# Altium schematic sheet Schematic.SchDoc(Schematic)
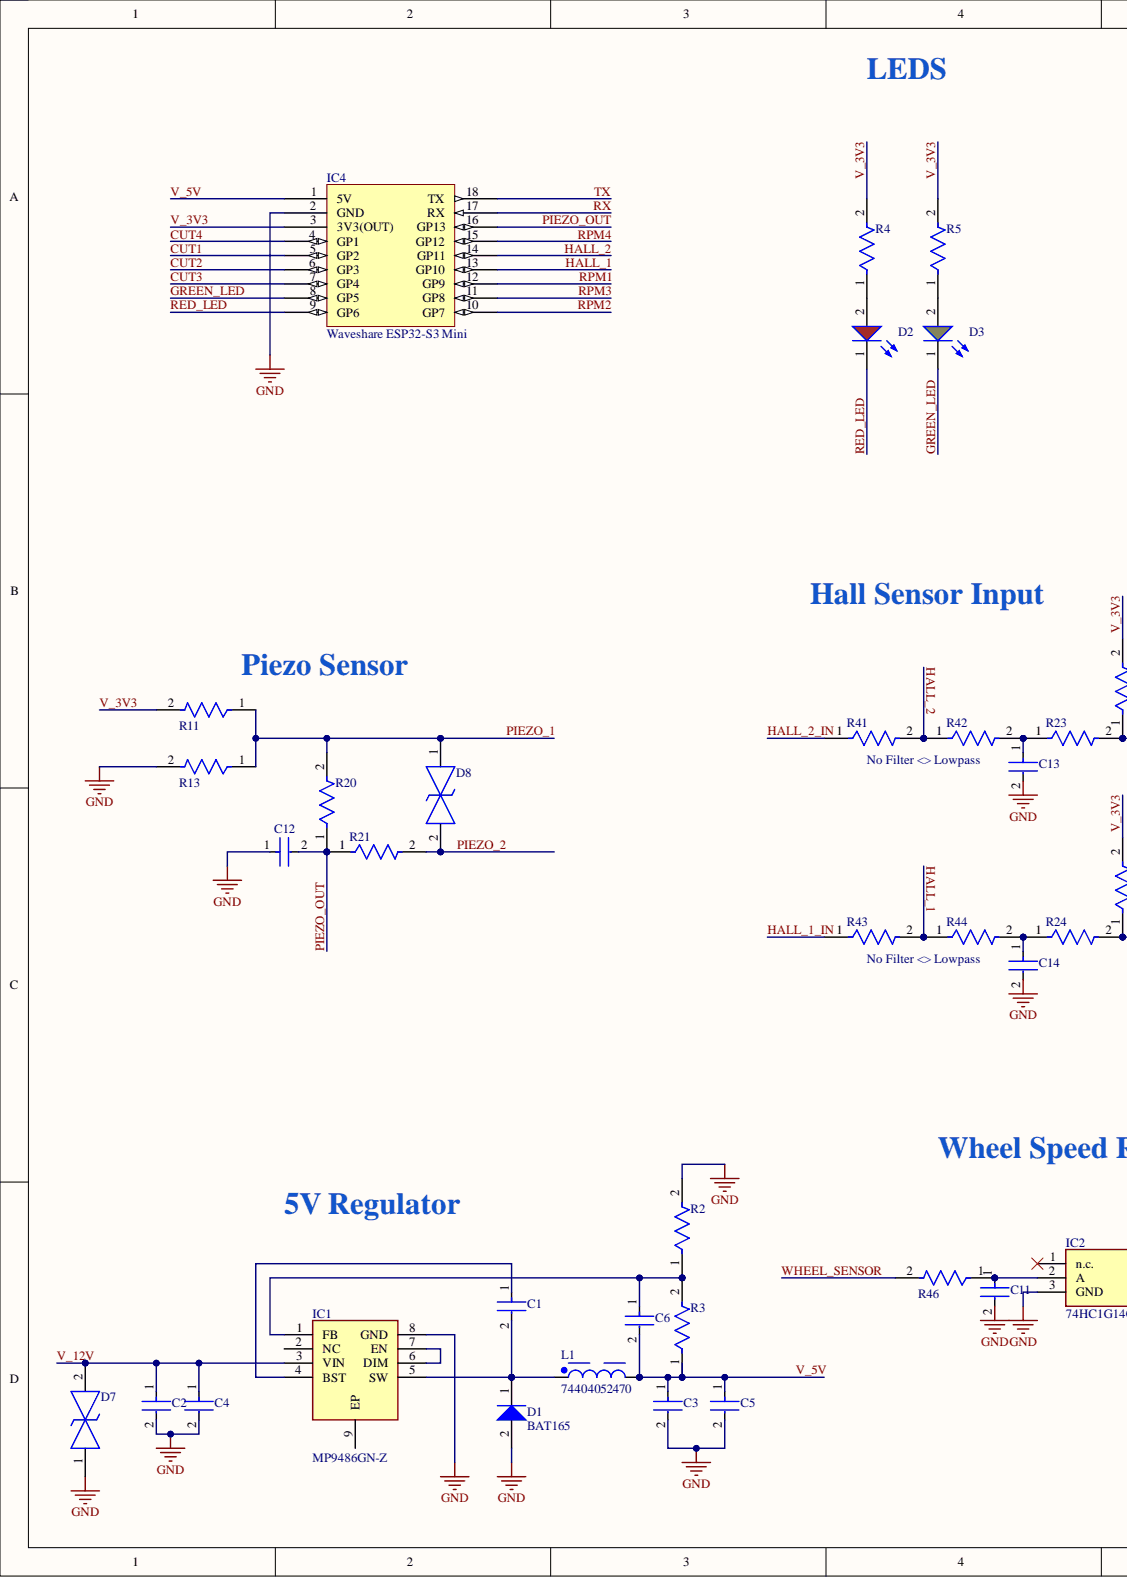
[diagram p0: Schematic.SchDoc, left]
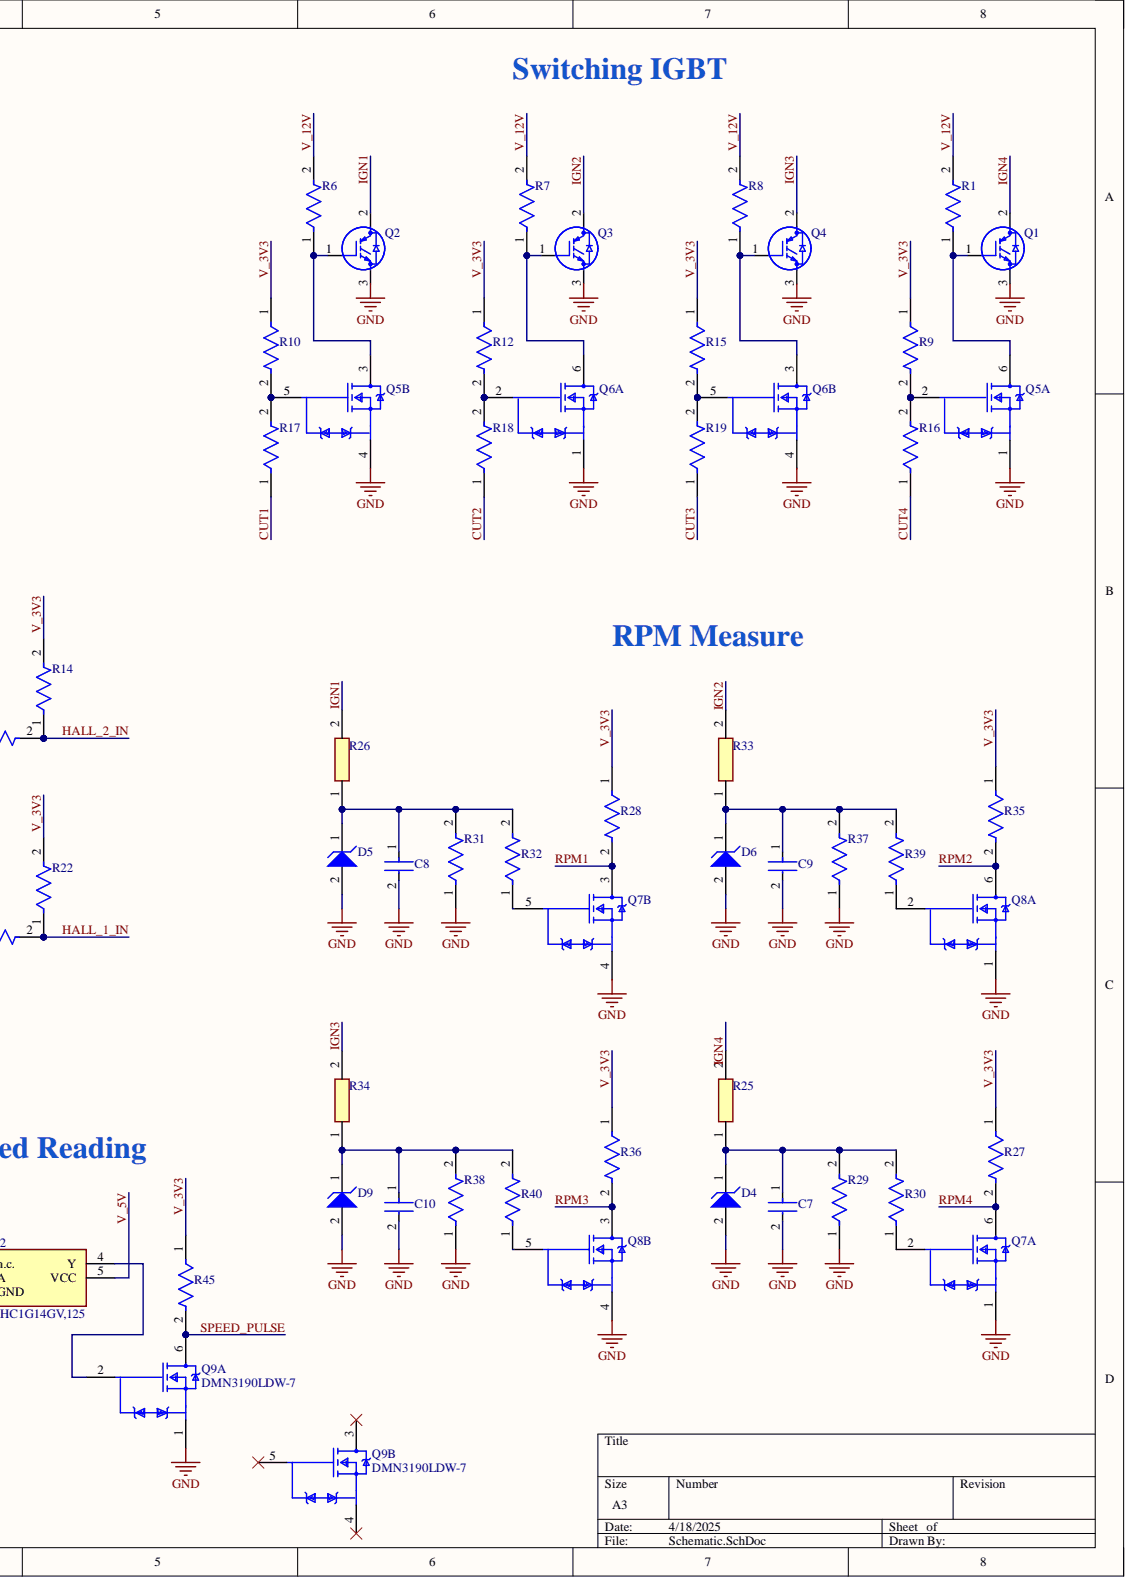
[diagram p1: Schematic.SchDoc, right]

## Parts
C1  C0603C104K3PACTU  (value not drawn)
C2  C3216X5R1E476M160AC  (value not drawn)
C3  C3216X5R1E476M160AC  (value not drawn)
C4  C0603C104K3PACTU  (value not drawn)
C5  C0603C225K4PACTU  (value not drawn)
C6  C0603C104K3PACTU  (value not drawn)
C7  C0603C333K1RECAUTO  (value not drawn)
C8  C0603C333K1RECAUTO  (value not drawn)
C9  C0603C333K1RECAUTO  (value not drawn)
C10  C0603C333K1RECAUTO  (value not drawn)
C11  C0603C103K5RACTU  (value not drawn)
C12  C0603C473K3RACTU  (value not drawn)
C13  CL10A105KA8NNNC  (value not drawn)
C14  CL10A105KA8NNNC  (value not drawn)
D1  BAT165
D2  150080RS75000  (value not drawn)
D3  150080GS75000  (value not drawn)
D4  SZMM3Z4V7T1G  (value not drawn)
D5  SZMM3Z4V7T1G  (value not drawn)
D6  SZMM3Z4V7T1G  (value not drawn)
D7  D20V0L1B2WS-7  (value not drawn)
D8  D20V0L1B2WS-7  (value not drawn)
D9  SZMM3Z4V7T1G  (value not drawn)
IC1  MP9486GN-Z
IC2  74HC1G14GV,125
IC4  Waveshare ESP32-S3 Mini
L1  74404052470
Q1  STGB20M65DF2  (value not drawn)
Q2  STGB20M65DF2  (value not drawn)
Q3  STGB20M65DF2  (value not drawn)
Q4  STGB20M65DF2  (value not drawn)
Q5  DMN3190LDW-7  (parts A,B)
Q6  DMN3190LDW-7  (parts A,B)
Q7  DMN3190LDW-7  (parts A,B)
Q8  DMN3190LDW-7  (parts A,B)
Q9  DMN3190LDW-7  (parts A,B)
R1  CRCW06033K30FKEA  (value not drawn)
R2  CRCW060310K0FKEC  (value not drawn)
R3  CRCW0603240KFKEA  (value not drawn)
R4  CRCW0603100RFKEB  (value not drawn)
R5  CRCW0603100RFKEB  (value not drawn)
R6  CRCW06033K30FKEA  (value not drawn)
R7  CRCW06033K30FKEA  (value not drawn)
R8  CRCW06033K30FKEA  (value not drawn)
R9  CRCW060310K0FKEC  (value not drawn)
R10  CRCW060310K0FKEC  (value not drawn)
R11  CRCW060310K0FKEC  (value not drawn)
R12  CRCW060310K0FKEC  (value not drawn)
R13  CRCW060310K0FKEC  (value not drawn)
R14  CRCW06033K30FKEA  (value not drawn)
R15  CRCW060310K0FKEC  (value not drawn)
R16  CRCW06031K00FKEA  (value not drawn)
R17  CRCW06031K00FKEA  (value not drawn)
R18  CRCW06031K00FKEA  (value not drawn)
R19  CRCW06031K00FKEA  (value not drawn)
R20  CRCW06032M00FKEA  (value not drawn)
R21  CRCW060310K0FKEC  (value not drawn)
R22  CRCW06033K30FKEA  (value not drawn)
R23  CRCW060320K0FKEA  (value not drawn)
R24  CRCW060320K0FKEA  (value not drawn)
R25  SDR10EZPJ103  (value not drawn)
R26  SDR10EZPJ103  (value not drawn)
R27  CRCW060310K0FKEC  (value not drawn)
R28  CRCW060310K0FKEC  (value not drawn)
R29  CRCW06033K00FKEA  (value not drawn)
R30  CRCW060310K0FKEC  (value not drawn)
R31  CRCW06033K00FKEA  (value not drawn)
R32  CRCW060310K0FKEC  (value not drawn)
R33  SDR10EZPJ103  (value not drawn)
R34  SDR10EZPJ103  (value not drawn)
R35  CRCW060310K0FKEC  (value not drawn)
R36  CRCW060310K0FKEC  (value not drawn)
R37  CRCW06033K00FKEA  (value not drawn)
R38  CRCW06033K00FKEA  (value not drawn)
R39  CRCW060310K0FKEC  (value not drawn)
R40  CRCW060310K0FKEC  (value not drawn)
R41  Radiation Hardening: No  (hidden comment: CRCW04020000Z0ED)
R42  CRCW04020000Z0ED  (value not drawn)
R43  Radiation Hardening: No  (hidden comment: CRCW04020000Z0ED)
R44  CRCW04020000Z0ED  (value not drawn)
R45  CRCW060310K0FKEC  (value not drawn)
R46  CRCW06032K20FKEC  (value not drawn)

## Nets
GND: C2.2 C3.2 C4.2 C5.2 C7.2 C8.2 C9.2 C10.2 C11.2 C12.1 C13.2 C14.2 D1.2 D4.2 D5.2 D6.2 D7.1 D9.2 IC1.8(GND) IC2.3(GND) IC4.2(GND) Q1.3 Q2.3 Q3.3 Q4.3 Q5.1 Q5.4 Q6.1 Q6.4 Q7.1 Q7.4 Q8.1 Q8.4 Q9.1 R2.2 R13.2 R29.1 R31.1 R37.1 R38.1
CUT1: IC4.5(GP2) R17.1
CUT2: IC4.6(GP3) R18.1
CUT3: IC4.7(GP4) R19.1
CUT4: IC4.4(GP1) R16.1
GREEN_LED: D3.1 IC4.8(GP5)
HALL_1: IC4.13(GP10) R43.2 R44.1
HALL_1_IN: R22.1 R24.2 R43.1
HALL_2: IC4.14(GP11) R41.2 R42.1
HALL_2_IN: R14.1 R23.2 R41.1
IGN1: Q2.2 R26.2
IGN2: Q3.2 R33.2
IGN3: Q4.2 R34.2
IGN4: Q1.2 R25.2
PIEZO_1: D8.1 R11.1 R13.1 R20.2
PIEZO_2: D8.2 R21.2
PIEZO_OUT: C12.2 IC4.16(GP13) R20.1 R21.1
RED_LED: D2.1 IC4.9(GP6)
RPM1: IC4.12(GP9) Q7.3 R28.2
RPM2: IC4.10(GP7) Q8.6 R35.2
RPM3: IC4.11(GP8) Q8.3 R36.2
RPM4: IC4.15(GP12) Q7.6 R27.2
RX: IC4.17(RX)
SPEED_PULSE: Q9.6 R45.2
TX: IC4.18(TX)
V_3V3: IC4.3(3V3(OUT)) R4.2 R5.2 R9.1 R10.1 R11.2 R12.1 R14.2 R15.1 R22.2 R27.1 R28.1 R35.1 R36.1 R45.1
V_5V: C3.1 C5.1 C6.2 IC2.5(VCC) IC4.1(5V) L1.2 R3.1
V_12V: C2.1 C4.1 D7.2 IC1.3(VIN) R1.2 R6.2 R7.2 R8.2
WHEEL_SENSOR: R46.2
NetC1_1: C1.1 IC1.4(BST)
NetC1_2: C1.2 D1.1 IC1.5(SW) L1.1
NetC6_1: C6.1 IC1.1(FB) R2.1 R3.2
NetC7_1: C7.1 D4.1 R25.1 R29.2 R30.2
NetC8_1: C8.1 D5.1 R26.1 R31.2 R32.2
NetC9_1: C9.1 D6.1 R33.1 R37.2 R39.2
NetC10_1: C10.1 D9.1 R34.1 R38.2 R40.2
NetC11_1: C11.1 IC2.2(A) R46.1
NetC13_1: C13.1 R23.1 R42.2
NetC14_1: C14.1 R24.1 R44.2
NetD2_2: D2.2 R4.1
NetD3_2: D3.2 R5.1
NetIC1_6: IC1.6(DIM) IC1.7(EN)
NetIC2_4: IC2.4(Y) Q9.2
NetQ1_1: Q1.1 Q5.6 R1.1
NetQ2_1: Q2.1 Q5.3 R6.1
NetQ3_1: Q3.1 Q6.6 R7.1
NetQ4_1: Q4.1 Q6.3 R8.1
NetQ5_2: Q5.2 R9.2 R16.2
NetQ5_5: Q5.5 R10.2 R17.2
NetQ6_2: Q6.2 R12.2 R18.2
NetQ6_5: Q6.5 R15.2 R19.2
NetQ7_2: Q7.2 R30.1
NetQ7_5: Q7.5 R32.1
NetQ8_2: Q8.2 R39.1
NetQ8_5: Q8.5 R40.1

Not connected: IC1 2(NC) 9(EP); IC2 1(n.c.); Q9 3 4 5

## Library data of the parts
C1 C4 C6 = CMP-03020-000352-2: C0603 0.10 μF X5R 30ppm/°C 10.00% 25 V; Manufacturer: KEMET; Automotive Grade: Grade 1; Capacitance: 0.10 μF; Material: Ceramic; Tolerance: 0.1; Value: 0.10 μF; Voltage Rating: 25 V; Automotive: Yes; Category: Cap; Component Kind: Standard; ComponentLink2Description: Datasheet; ComponentLink2URL: https://api.kemet.com/component-edge/download/datasheet/C0603C100J5GACAUTO.pdf; Device Class L1: Passive Components; Device Class L2: Capacitors; Device Class L3: Ceramic Capacitors; Height: 0.87mm; Lead Free: Yes; Library Name: Altium Content Vault; Manufacturer Part Number: C0603C104K3PACTU; Mouser Description: Multilayer Ceramic Capacitors MLCC - SMD/SMT 25volts 0.1uF 10% X5R; Octopart: https://octopart.com/c0603c104k3pactu-kemet-19022554; Package: C0603C-CF; RoHS: TRUE; Temperature: -55°C to +125°C; Temperature Characteristic: X5R; Temperature Coefficient: 30ppm/°C; Temperature Range High: +125°C; Temperature Range Low: -55°C
C2 C3 = CMP-2000-05397-3: Multilayer Ceramic Capacitors 47uF ±20% 25V X5R SMD 1206; Capacitance: 47uF; Case Code (Imperial): 1206; Case Code (Metric): 3216; Case/Package: 1206; Component Kind: Standard; Depth: 1.6mm; Dielectric: X5R; Dielectric Material: Ceramic; Height: 1.6mm; Lead Free: Lead Free; Length: 0.126inch; Library Name: Altium Content Vault; Max Operating Temperature: 85°C; Min Operating Temperature: -55°C; Mount: Surface Mount; Package Quantity: 1; Packaging: Tape and Reel; REACH SVHC: No SVHC; Resistor Type: Ceramic; RoHS Compliant: Yes; Series: C; Termination: SMD/SMT; Thickness: 0.071inch; Tolerance: 20%; Voltage: 25V; Voltage Rating: 25V; Voltage Rating (DC): 25V; Weight: 0.000571oz; Width: 0.063inch
C5 = CMP-2006-02259-2: CAP CER 2.2UF 16V X5R 0603; Dielectric Material: Ceramic; Dissipation Factor: 5%; Tolerance: 10%; Capacitance: 2.2uF; Case Code (Imperial): 0603; Case Code (Metric): 1608; Case/Package: 0603; Component Kind: Standard; Construction: Flat; Contact Plating: Tin; Depth: 0.8mm; Dielectric: X5R; Height: 0.8mm; Lead Free: Lead Free; Length: 1.6mm; Library Name: Altium Content Vault; Max Operating Temperature: 85°C; Min Operating Temperature: -55°C; Mount: Surface Mount; Number of Pins: 2; Package Quantity: 1; Packaging: Tape and Reel; Radiation Hardening: No; REACH SVHC: No SVHC; RoHS Compliant: Yes; Series: C; Termination: SMD/SMT; Thickness: 0.034inch; Voltage: 16V; Voltage Rating: 16V; Voltage Rating (DC): 16V; Width: 0.8mm
C7-C10 = CMP-00212-78735637-1: C0603C333K1RECAUTO 0603 (1608METRIC) Ceramic Capacitor Esd SMD Auto X7R 100V 0.033MUF ±10% X7R; Capacitance: 33nF; Component Kind: Standard; Dielectric: X7R; Library Name: Altium Content Vault; NexarPartId: 78735637; Packaging: Cut Tape; RoHS: Non-Compliant; Voltage Rating (DC): 100V
C11 = CMP-2006-03046-3: CAP CER 10000PF 50V X7R 0603; Manufacturer: KEMET; Automotive Grade: Grade 1; Capacitance: 10,000 pF; Material: Ceramic; Temperature Coefficient: 30ppm/°C; Tolerance: 0.1; Value: 10,000 pF; Automotive: Yes; Category: Cap; Component Kind: Standard; ComponentLink2Description: Datasheet; ComponentLink2URL: https://api.kemet.com/component-edge/download/datasheet/C0603C100J5GACAUTO.pdf; Device Class L1: Passive Components; Device Class L2: Capacitors; Device Class L3: Ceramic Capacitors; Digikey Description: CAP CER 10000PF 50V X7R 0603; Height: 0.87mm; Lead Free: Yes; Library Name: Altium Content Vault; Manufacturer Part Number: C0603C103K5RACTU; Mouser Description: Ceramic Capacitor 0.01Uf, 50V, X7R, 10%, 0603, Full Reel; Octopart: https://octopart.com/c0603c103k5ractu-kemet-133094; Package: C0603C-CF; RoHS: TRUE; Temperature: -55°C to +125°C; Temperature Characteristic: X7R; Temperature Range High: +125°C; Temperature Range Low: -55°C; Voltage Rating: 50 V
C12 = CMP-2006-03882-2: CAP CER 0.047UF 25V 10% X7R 0603; Manufacturer: KEMET; Automotive Grade: Grade 1; Capacitance: 47,000 pF; Material: Ceramic; Temperature Coefficient: 30ppm/°C; Tolerance: 0.1; Value: 47,000 pF; Automotive: Yes; Category: Cap; Component Kind: Standard; ComponentLink2Description: Datasheet; ComponentLink2URL: https://api.kemet.com/component-edge/download/datasheet/C0603C100J5GACAUTO.pdf; Device Class L1: Passive Components; Device Class L2: Capacitors; Device Class L3: Ceramic Capacitors; Digikey Description: CAP CER 0.047UF 25V 10% X7R 0603; Height: 0.87mm; Lead Free: Yes; Library Name: Altium Content Vault; Manufacturer Part Number: C0603C473K3RACTU; Mouser Description: Multilayer Ceramic Capacitor, C Series, 0.047 - F, - 10%, X7R, 25 V, 0603 [1608 Metric] Rohs Compliant: Yes; Octopart: https://octopart.com/c0603c473k3ractu-kemet-3834514; Package: C0603C-CF; RoHS: TRUE; Temperature: -55°C to +125°C; Temperature Characteristic: X7R; Temperature Range High: +125°C; Temperature Range Low: -55°C; Voltage Rating: 25 V
C13 C14 = CMP-13271-004127-1: Cap Ceramic 1uF 25V X5R ±10% Pad SMD 0603 +85°C T/R; Capacitance: 1uF; Case Code (Imperial): 0603; Case Code (Metric): 1608; Case/Package: 0603; Component Kind: Standard; Contact Plating: Tin; Depth: 0.8mm; Dielectric: X5R; Height: 0.8mm; Lead Free: Lead Free; Length: 1.6mm; Library Name: Altium Content Vault; Material: Ceramic; Max Operating Temperature: 85°C; Min Operating Temperature: -55°C; Mount: Surface Mount; Package Quantity: 1; Packaging: Tape and Reel; Radiation Hardening: No; Thickness: 0.035inch; Tolerance: 10%; Voltage: 25V; Voltage Rating: 25V; Voltage Rating (DC): 25V; Width: 0.031inch
D1 = CMP-1183-00004-1: Medium Power AF Schottky Diode, SOD323, Reel, Green; Manufacturer: Infineon; Configuration: Single; CT (max): 12Â pF; Green: TRUE; IF (max): 750Â mA; VF (max): 700Â mV; VR (max): 40Â V; Component Kind: Standard; ComponentLink1Description: Manufacturer URL; ComponentLink1URL: http://www.infineon.com/; ComponentLink2Description: Datasheet; ComponentLink2URL: http://www.infineon.com/dgdl/bat165series.pdf?folderId=db3a304313d846880113def5812204a1&fileId=db3a304313d846880113df01f69804d6; ComponentLink3Description: Package Specification; ComponentLink3URL: http://www.infineon.com/dgdl/SOD323-Package_Overview.pdf?folderId=db3a30431936bc4b011952aab84f3bbe&fileId=db3a30431936bc4b011952beed653be3; Library Name: Altium Content Vault; Mounting Technology: Surface Mount; PackageDescription: 2-Pin Small Outline Diode Package, 1.25 x 1.7 mm Body; PackageReference: SOD323; Packing: Reel; PartNumber: BAT165
D2 = CMP-1426-00011-2: NexarPartId: 29717781; Color: Red; Dominant Wavelength: 625nm; Forward Current: 100mA; Forward Voltage: 2V; Lens Style: Clear, Rectangular; Luminous Intensity: 150mcd; Max Reverse Voltage (DC): 5V; Peak Wavelength: 630nm; Test Current: 20mA; Viewing Angle: 140°; Case Code (Imperial): 0805; Case Code (Metric): 2012; Case/Package: 0805; Component Kind: Standard; Height: 700µm; Length: 2mm; Library Name: Altium Content Vault; Max Operating Temperature: 85°C; Min Operating Temperature: -40°C; Mount: Surface Mount; Packaging: Tape and Reel; REACH SVHC: Unknown; RoHS: Compliant; Wavelength: 625nm; Width: 1.25mm
D3 = CMP-1426-00007-2: NexarPartId: 29717780; Color: Green; Dominant Wavelength: 525nm; Forward Current: 100mA; Forward Voltage: 3.2V; Lens Style: Clear, Rectangular; Luminous Intensity: 450mcd; Max Junction Temperature (Tj): 95°C; Max Reverse Voltage (DC): 5V; Peak Wavelength: 515nm; Power Dissipation: 105mW; Test Current: 20mA; Viewing Angle: 140°; Wavelength: 520nm; Case Code (Imperial): 0805; Case Code (Metric): 2012; Case/Package: 0805; Component Kind: Standard; Height: 700µm; Length: 2mm; Library Name: Altium Content Vault; Max Operating Temperature: 85°C; Min Operating Temperature: -40°C; Mount: Surface Mount; Packaging: Tape and Reel; REACH SVHC: Unknown; Reverse Voltage: 5V; RoHS: Compliant; Width: 1.25mm
D4-D6 D9 = CMP-1060-00696-1: Zener Voltage Regulator, 300 mW, 2-Pin SOD-323, Pb-Free, Tape and Reel; Manufacturer: On Semiconductor; P Max (W): 0.3; VZ Typ (V): 4.7; Component Kind: Standard; ComponentLink1Description: Manufacturer URL; ComponentLink1URL: http://www.onsemi.com/; ComponentLink2Description: Datasheet; ComponentLink2URL: http://www.onsemi.cn/pub_link/Collateral/MM3Z2V4T1-D.PDF; ComponentLink3Description: Package Specification; ComponentLink3URL: http://www.onsemi.com/pub_link/Collateral/477-02.PDF.1; DatasheetVersion: Rev. 9; Library Name: Altium Content Vault; Mounting Technology: Surface Mount; PackageDescription: 2-Pin SOD, Body 1.7 x 1.25 mm; PackageReference: SOD-323-2-477-02; PackageVersion: Rev. H, 03/2007; Packing: Tape and Reel; PartNumber: SZMM3Z4V7T1G; RoHS: Pb-Free
D7 D8 = D20V0L1B2WS-7: TVS Diode (Bi-directional); Manufacturer_Name: Diodes Incorporated; Component Kind: Standard; Datasheet Link: https://www.diodes.com/assets/Datasheets/D20V0L1B2WSQ.pdf; Height: 1.2mm; Manufacturer_Part_Number: D20V0L1B2WS-7
IC1 = MP9486GN-Z: Integrated Circuit; Manufacturer_Name: Monolithic Power Systems (MPS); Component Kind: Standard; Datasheet Link: https://www.monolithicpower.com/en/documentview/productdocument/index/version/2/document_type/Datasheet/lang/en/sku/MP9486/; Height: 1.7mm; Manufacturer_Part_Number: MP9486GN-Z; Mouser Part Number: 946-MP9486GN-Z; Mouser Price/Stock: https://www.mouser.co.uk/ProductDetail/Monolithic-Power-Systems-MPS/MP9486GN-Z?qs=YCa%2FAAYMW039h5ckyQnXtA%3D%3D
IC2 = 74HC1G14GV,125: Integrated Circuit; Manufacturer_Name: Nexperia; Arrow Part Number: 74HC1G14GV,125; Arrow Price/Stock: https://www.arrow.com/en/products/74hc1g14gv125/nexperia?utm_currency=USD&region=europe; Component Kind: Standard; Datasheet Link: https://assets.nexperia.com/documents/data-sheet/74HC_HCT1G14.pdf; Height: 1.1mm; Manufacturer_Part_Number: 74HC1G14GV,125; Mouser Part Number: 771-HC1G14GV125; Mouser Price/Stock: https://www.mouser.co.uk/ProductDetail/Nexperia/74HC1G14GV125?qs=P62ublwmbi8dww6YrwOPwQ%3D%3D
IC4 = CMP-00000-1: WaveShare ESP32-S3 Mini Development Board ESP32-S3FH4R2 240MHz 2.4GHz Wi-Fi Bluetooth 5; Component Kind: Standard; Datasheet: https://www.waveshare.com/wiki/ESP32-S3-Zero; Library Name: Sandros Projects
L1 = CMP-03913-61645225-1: 74404052470 Inductors, Fixed, WE-LQS SMD 5020 47uH 0.8A 521mOhms; Current Rating: 800mA; DC Resistance (DCR): 521mΩ; Inductance: 47µH; Inductance Tolerance: 20%; Saturation Current: 950mA; Self Resonant Frequency: 11MHz; Shielding: Shielded; Test Frequency: 100kHz; Ambient Temperature Range High: 85°C; Ambient Temperature Range Low: -40°C; Case/Package: Nonstandard; Component Kind: Standard; Composition: Wirewound; Height: 2mm; Height - Seated (Max): 2.0066mm; Length: 5mm; Library Name: Altium Content Vault; Max Operating Temperature: 125°C; Min Operating Temperature: -40°C; Mount: Surface Mount; NexarPartId: 61645225; Packaging: Tape & Reel (TR); RoHS: Compliant; Tolerance: 20%; Width: 5mm
Q1-Q4 = STGB20M65DF2: Transistor IGBT; Manufacturer_Name: STMicroelectronics; Component Kind: Standard; Datasheet Link: https://www.st.com/resource/en/datasheet/stgb20m65df2.pdf; Height: 4.83mm; Manufacturer_Part_Number: STGB20M65DF2; Mouser Part Number: 511-STGB20M65DF2; Mouser Price/Stock: https://www.mouser.com/Search/Refine.aspx?Keyword=511-STGB20M65DF2
Q5-Q9 = CMP-12801-000100-1: MOSFET 2N-CH 30V 1A SOT363; Drain to Source Resistance: 190mR; Element Configuration: Dual; Fall Time: 15.6ns; FET Type: 2 N-Channel; Gate to Source Voltage (Vgs): 20V; Input Capacitance: 87pF; Max Power Dissipation: 320mW; Number of Channels: 2; Rise Time: 8.9ns; Turn-Off Delay Time: 30.3ns; Turn-On Delay Time: 4.5ns; Case/Package: SOT-363; Component Kind: Standard; Continuous Drain Current (ID): 1A; Drain to Source Voltage (Vdss): 30V; Height: 1mm; Lead Free: Lead Free; Length: 2.2mm; Library Name: Altium Content Vault; Max Operating Temperature: 150°C; Min Operating Temperature: -55°C; Mount: Surface Mount; Number of Pins: 6; Package Quantity: 3000; Packaging: Tape and Reel; Radiation Hardening: No; Rds On Max: 190mR; Weight: 0.000212oz; Width: 1.35mm
R1 R6-R8 R14 R22 = CMP-2100-03614-2: RES Thick Film, 3.3kΩ, 1%, 0.1W, 100ppm/°C, 0603; Max Power Dissipation: 100mW; Resistance: 3.3kR; Voltage Rating: 75V; Case Code (Imperial): 0603; Case Code (Metric): 1608; Case/Package: 0603; Component Kind: Standard; Composition: Thick Film; Contact Plating: Tin; Depth: 0.85mm; Features: Automotive AEC-Q200; Height: 0.45mm; Lead Free: Lead Free; Length: 1.55mm; Library Name: Altium Content Vault; Max Operating Temperature: 155°C; Military Standard: Not; Min Operating Temperature: -55°C; Mount: Surface Mount; Number of Terminations: 2; Package Quantity: 10; Packaging: Tape and Reel; Power Rating: 100mW; Radiation Hardening: No; Temperature Coefficient: 100ppm/°C; Termination: SMD/SMT; Tolerance: 1%; Voltage Rating (DC): 75V; Weight: 7.1E-05oz; Width: 0.85mm; Working Voltage: 75V
R2 R9-R13 R15 R21 R27 R28 R30 R32 R35 R36 R39 R40 R45 = CMP-02407-006151-1: RES Thick Film, 10kΩ, 1%, 0.1W, 100ppm/°C, 0603; Max Power Dissipation: 100mW; Resistance: 10kR; Case Code (Imperial): 0603; Case Code (Metric): 1608; Case/Package: 0603; Component Kind: Standard; Composition: Thick Film; Contact Plating: Tin; Depth: 0.85mm; Features: Automotive AEC-Q200; Height: 0.02inch; Lead Free: Lead Free; Length: 0.061inch; Library Name: Altium Content Vault; Max Operating Temperature: 155°C; Military Standard: Not; Min Operating Temperature: -55°C; Number of Terminations: 2; Packaging: Tape and Reel; Power Rating: 100mW; Radiation Hardening: No; Temperature Coefficient: 100ppm/°C; Tolerance: 1%; Width: 0.033inch
R3 = CMP-02407-008686-1: RES Thick Film, 240kΩ, 1%, 0.1W, 100ppm/°C, 0603; Max Power Dissipation: 100mW; Resistance: 240kR; Voltage Rating: 75V; Case Code (Imperial): 0603; Case Code (Metric): 1608; Case/Package: 0603; Component Kind: Standard; Composition: Thick Film; Contact Plating: Tin; Depth: 0.85mm; Features: Automotive AEC-Q200; Height: 0.45mm; Lead Free: Lead Free; Length: 1.55mm; Library Name: Altium Content Vault; Max Operating Temperature: 155°C; Military Standard: Not; Min Operating Temperature: -55°C; Number of Terminations: 2; Package Quantity: 10; Packaging: Tape and Reel; Power Rating: 100mW; Radiation Hardening: No; Temperature Coefficient: 100ppm/°C; Termination: SMD/SMT; Tolerance: 1%; Voltage Rating (DC): 75V; Weight: 7.1E-05oz; Width: 0.033inch
R4 R5 = CMP-2000-03825-2: RES Thick Film, 100Ω, 1%, 0.1W, 100ppm/°C, 0603; Max Power Dissipation: 100mW; Resistance: 100R; Voltage Rating: 75V; Case Code (Imperial): 0603; Case Code (Metric): 1608; Case/Package: 0603; Component Kind: Standard; Composition: Thick Film; Contact Plating: Tin; Depth: 0.85mm; Features: Automotive AEC-Q200; Height: 0.02inch; Lead Free: Lead Free; Length: 1.55mm; Library Name: Altium Content Vault; Max Operating Temperature: 155°C; Military Standard: Not; Min Operating Temperature: -55°C; Number of Terminations: 2; Package Quantity: 10000; Packaging: Tape and Reel; Power Rating: 100mW; Radiation Hardening: No; Temperature Coefficient: 100ppm/°C; Termination: SMD/SMT; Tolerance: 1%; Voltage Rating (DC): 75V; Weight: 7.1E-05oz; Width: 0.033inch
R16-R19 = CMP-1666-00003-5: RES Thick Film, 1kΩ, 1%, 0.1W, 100ppm/°C, 0603; Max Power Dissipation: 100mW; Resistance: 1kR; Voltage Rating: 75V; Case Code (Imperial): 0603; Case Code (Metric): 1608; Case/Package: 0603; Component Kind: Standard; Composition: Thick Film; Contact Plating: Tin; Depth: 0.85mm; Features: Automotive AEC-Q200; Height: 0.45mm; Lead Free: Lead Free; Length: 1.55mm; Library Name: Altium Content Vault; Max Operating Temperature: 155°C; Military Standard: Not; Min Operating Temperature: -55°C; Mount: Surface Mount; Number of Pins: 2; Number of Terminations: 2; Package Quantity: 10; Packaging: Tape and Reel; Power Rating: 100mW; Radiation Hardening: No; Temperature Coefficient: 100ppm/°C; Termination: SMD/SMT; Tolerance: 1%; Voltage Rating (DC): 75V; Weight: 7.1E-05oz; Width: 0.033inch
R20 = CMP-2000-03189-2: RES Thick Film, 2MΩ, 1%, 0.1W, 100ppm/°C, 0603; Max Power Dissipation: 100mW; Resistance: 2MR; Voltage Rating: 75V; Case Code (Imperial): 0603; Case Code (Metric): 1608; Case/Package: 0603; Component Kind: Standard; Composition: Thick Film; Contact Plating: Tin; Depth: 0.85mm; Features: Automotive AEC-Q200; Height: 0.45mm; Lead Free: Lead Free; Length: 1.55mm; Library Name: Altium Content Vault; Max Operating Temperature: 155°C; Military Standard: Not; Min Operating Temperature: -55°C; Number of Pins: 2; Number of Terminations: 2; Package Quantity: 10; Packaging: Tape and Reel; Power Rating: 100mW; Radiation Hardening: No; Temperature Coefficient: 100ppm/°C; Termination: SMD/SMT; Tolerance: 1%; Voltage Rating (DC): 75V; Weight: 7.1E-05oz; Width: 0.033inch; Working Voltage: 75V
R23 R24 = CMP-2000-03068-2: RES Thick Film, 20kΩ, 1%, 0.1W, 100ppm/°C, 0603; Power Rating: 100mW; Resistance: 20kR; Voltage Rating: 75V; Case Code (Imperial): 0603; Case Code (Metric): 1608; Case/Package: 0603; Component Kind: Standard; Composition: Thick Film; Contact Plating: Tin; Depth: 0.85mm; Height: 0.45mm; Lead Free: Lead Free; Length: 1.55mm; Library Name: Altium Content Vault; Max Operating Temperature: 155°C; Military Standard: Not; Min Operating Temperature: -55°C; Mount: Surface Mount; Number of Pins: 2; Package Quantity: 5000; Packaging: Tape and Reel; Radiation Hardening: No; Temperature Coefficient: 100ppm/°C; Termination: SMD/SMT; Tolerance: 1%; Voltage Rating (DC): 75V; Weight: 7.1E-05oz; Width: 0.85mm
R25 R26 R33 R34 = SDR10EZPJ103: Resistor; Manufacturer_Name: ROHM Semiconductor; Component Kind: Standard; Datasheet Link: https://nl.mouser.com/datasheet/2/348/sdr_e-3009658.pdf; Height: 0.65mm; Manufacturer_Part_Number: SDR10EZPJ103; Mouser Part Number: 755-SDR10EZPJ103; Mouser Price/Stock: https://www.mouser.co.uk/ProductDetail/ROHM-Semiconductor/SDR10EZPJ103?qs=MyNHzdoqoQJSCwoO%252BTUQCQ%3D%3D
R29 R31 R37 R38 = CMP-2000-03293-2: RES Thick Film, 3kΩ, 1%, 0.1W, 100ppm/°C, 0603; Max Power Dissipation: 100mW; Resistance: 3kR; Voltage Rating: 75V; Case Code (Imperial): 0603; Case Code (Metric): 1608; Case/Package: 0603; Component Kind: Standard; Composition: Thick Film; Contact Plating: Tin; Depth: 0.85mm; Features: Automotive AEC-Q200; Height: 0.45mm; Lead Free: Lead Free; Length: 1.55mm; Library Name: Altium Content Vault; Max Operating Temperature: 155°C; Military Standard: Not; Min Operating Temperature: -55°C; Mount: Surface Mount; Number of Terminations: 2; Package Quantity: 10; Packaging: Tape and Reel; Power Rating: 100mW; Radiation Hardening: No; Temperature Coefficient: 100ppm/°C; Termination: SMD/SMT; Tolerance: 1%; Voltage Rating (DC): 75V; Weight: 7.1E-05oz; Width: 0.85mm
R41-R44 = CMP-2002-08122-2: RES Thick Film, 0Ω, 0.063W, 0402; Max Power Dissipation: 63mW; Resistance: 0mR; Temperature Coefficient: 100ppm/°C; Tolerance: 5%; Voltage Rating: 50V; Case Code (Imperial): 0402; Case Code (Metric): 1005; Case/Package: 0402; Component Kind: Standard; Composition: Thick Film; Contact Plating: Tin; Depth: 0.5mm; Features: Automotive AEC-Q200; Height: 0.35mm; Lead Free: Lead Free; Length: 1mm; Library Name: Altium Content Vault; Max Operating Temperature: 155°C; Military Standard: Not; Min Operating Temperature: -55°C; Mount: Surface Mount; Number of Terminations: 2; Package Quantity: 1; Packaging: Tape and Reel; Power Rating: 63mW; Termination: SMD/SMT; Voltage Rating (DC): 50V; Weight: 2.3E-05oz; Width: 0.5mm
  R42 R44: Radiation Hardening: No
R46 = CMP-1012-00546-2: RES Thick Film, 2.2kΩ, 1%, 0.1W, 100ppm/°C, 0603; Max Power Dissipation: 100mW; Resistance: 2.2kR; Case/Package: 0603; Component Kind: Standard; Composition: Thick Film; Contact Plating: Tin; Depth: 0.85mm; Features: Automotive AEC-Q200; Height: 0.02inch; Lead Free: Lead Free; Length: 0.061inch; Library Name: Altium Content Vault; Max Operating Temperature: 155°C; Military Standard: Not; Min Operating Temperature: -55°C; Number of Terminations: 2; Package Quantity: 20000; Packaging: Tape and Reel; Radiation Hardening: No; Temperature Coefficient: 100ppm/°C; Tolerance: 1%; Width: 0.033inch
